# Altium schematic sheet cooling_fan.SchDoc
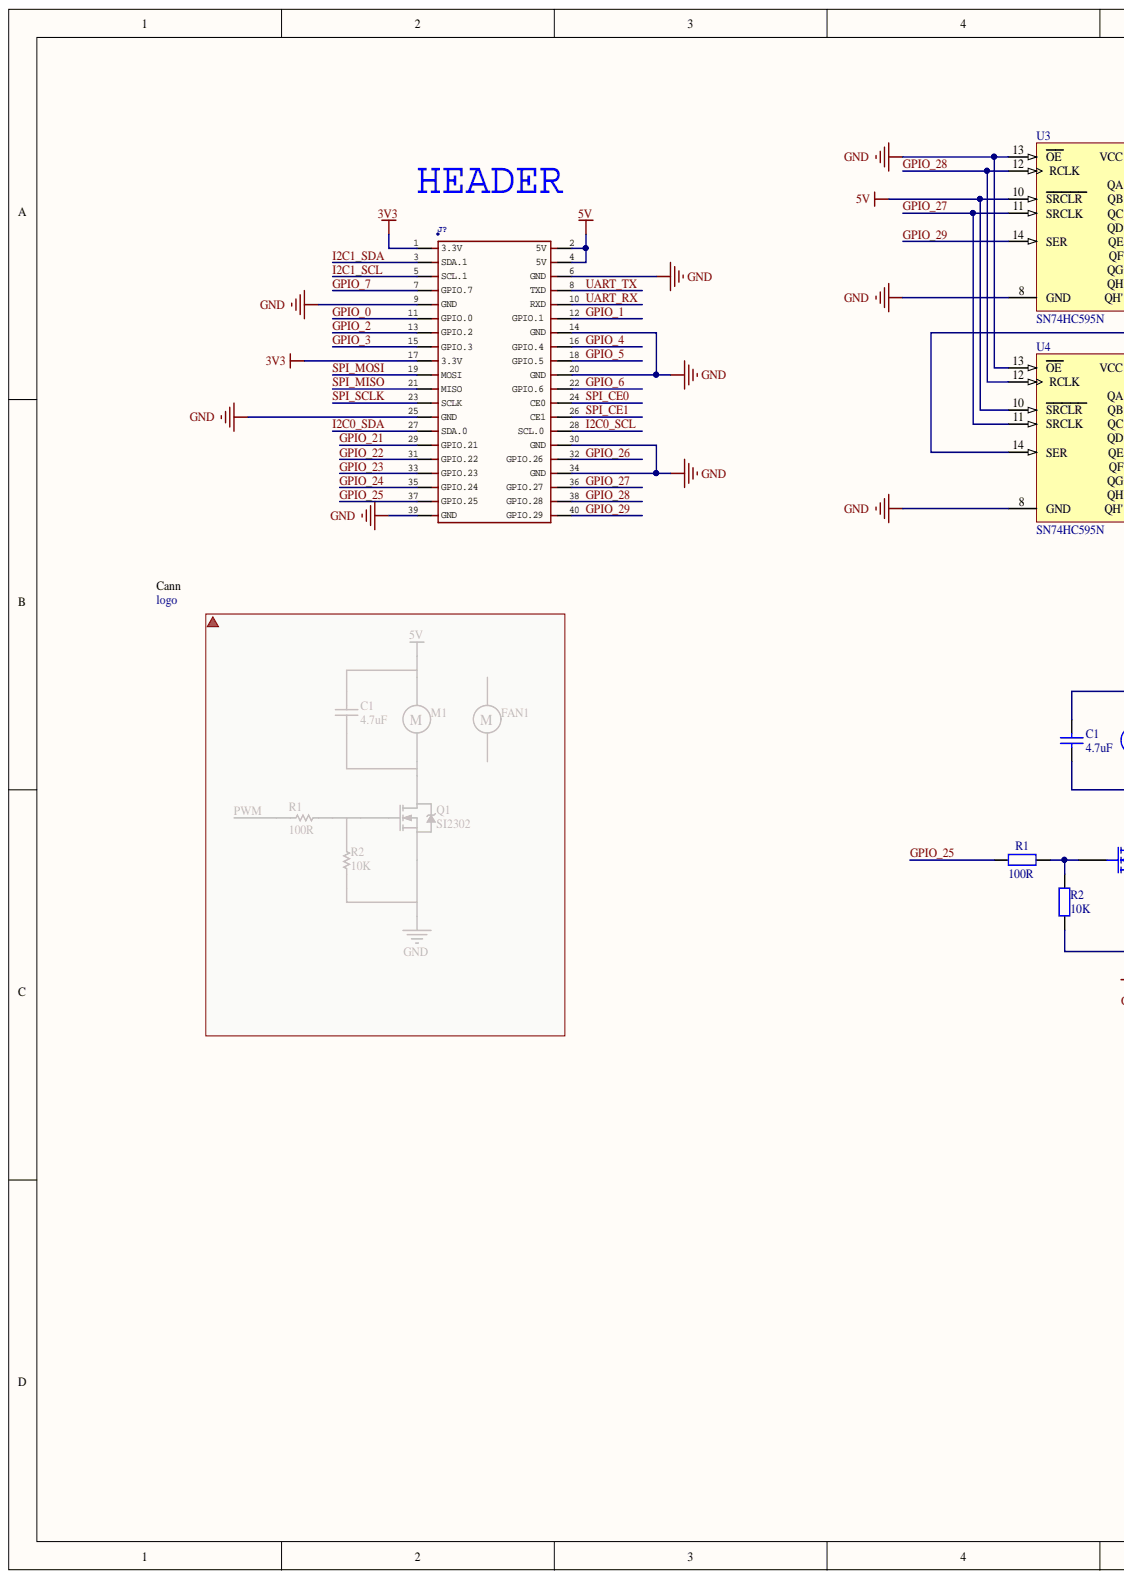
[diagram p0: cooling_fan.SchDoc, left]
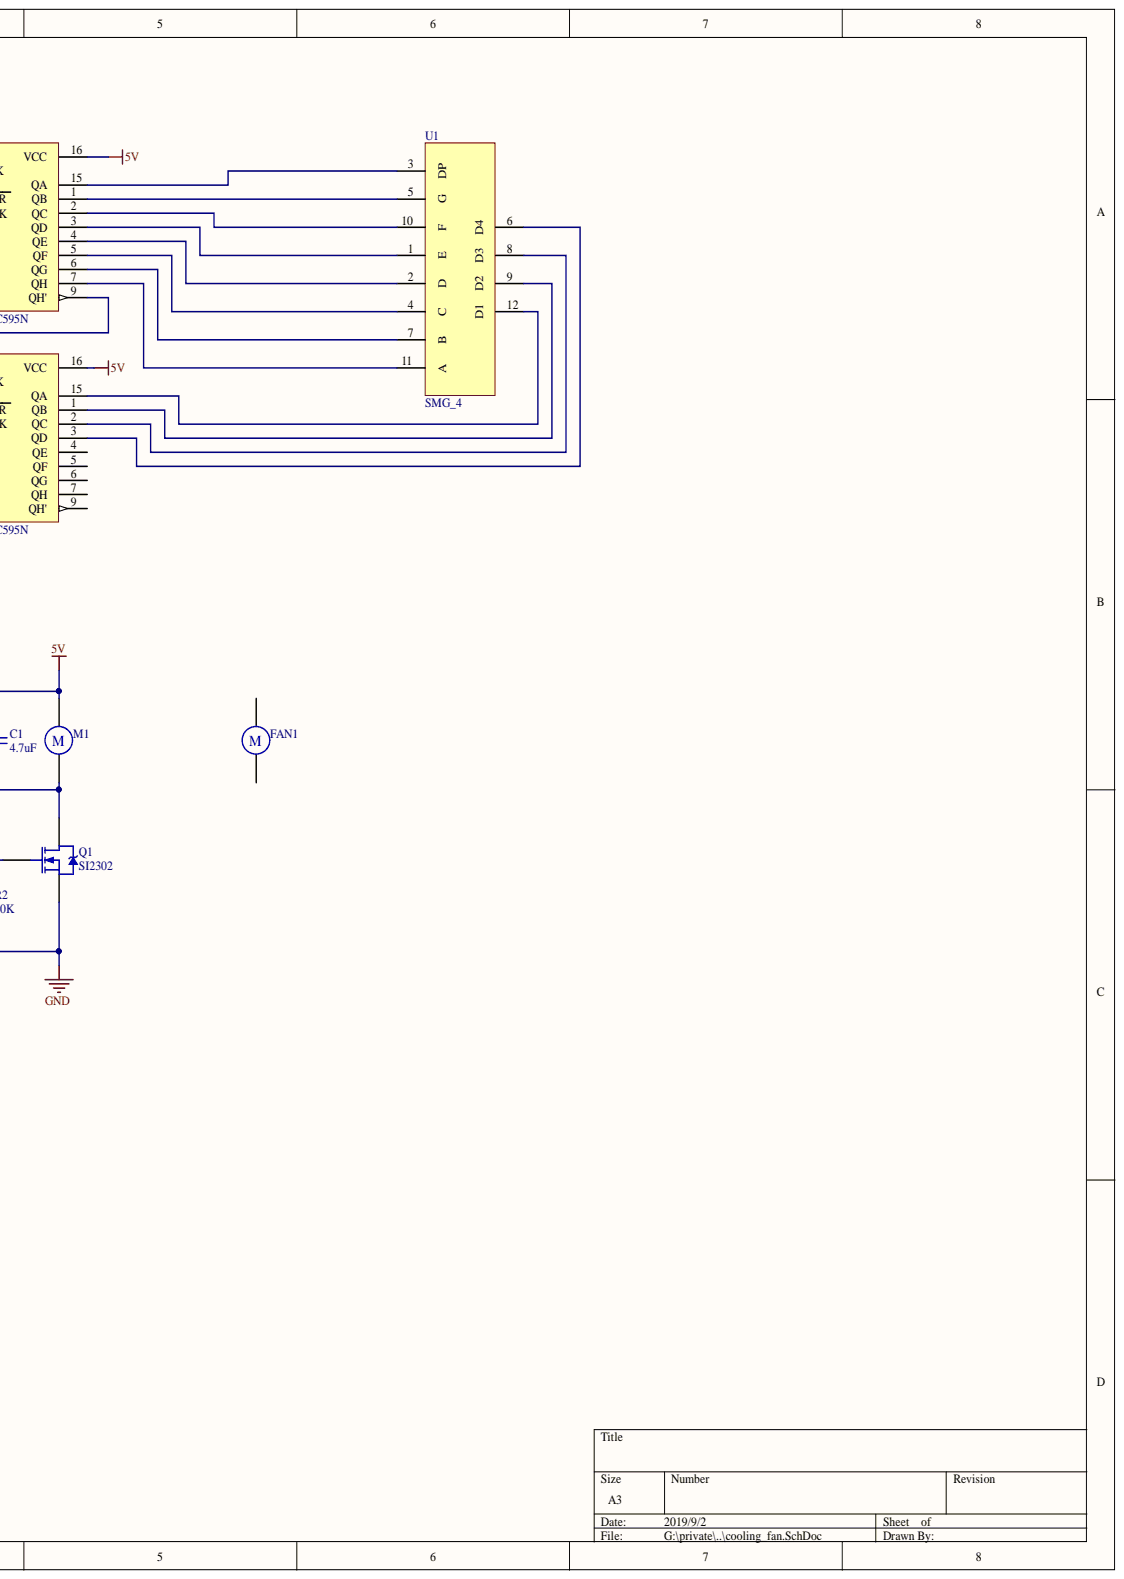
[diagram p1: cooling_fan.SchDoc, right]

## Parts
C1  value 4.7uF  [0603_C]  (hidden comment: C_0603)
FAN1  Motor_720  [FAN]  (value not drawn)
J?  [320030075]2*13P-2.54  [HEADER_20X2]  (value not drawn)
logo1  logo  [LOGO_muselab]
M1  Motor_720  [PH2.0-WS-2P]  (value not drawn)
Q1  SI2302  [SOT-23(SOT-23-3)]
R1  value 100R  [0603_R]  (hidden comment: R_0603)
R2  value 10K  [0603_R]  (hidden comment: R_0603)
U1  SMG_4  [SMG 0.28-4P_B]
U3  SN74HC595N  [SOP16N_M]
U4  SN74HC595N  [SOP16N_M]

## Nets
3V3: J?.1(3.3V) J?.17(3.3V)
5V: C1.2 J?.2(5V) J?.4(5V) M1.1 U3.10(SRCLR) U3.16(VCC) U4.10(SRCLR) U4.16(VCC)
GND: J?.6(GND) J?.9(GND) J?.14(GND) J?.20(GND) J?.25(GND) J?.30(GND) J?.34(GND) J?.39(GND) Q1.2 R2.1 U3.8(GND) U3.13(OE) U4.8(GND) U4.13(OE)
GPIO_0: J?.11(GPIO.0)
GPIO_1: J?.12(GPIO.1)
GPIO_2: J?.13(GPIO.2)
GPIO_3: J?.15(GPIO.3)
GPIO_4: J?.16(GPIO.4)
GPIO_5: J?.18(GPIO.5)
GPIO_6: J?.22(GPIO.6)
GPIO_7: J?.7(GPIO.7)
GPIO_21: J?.29(GPIO.21)
GPIO_22: J?.31(GPIO.22)
GPIO_23: J?.33(GPIO.23)
GPIO_24: J?.35(GPIO.24)
GPIO_25: J?.37(GPIO.25) R1.1
GPIO_26: J?.32(GPIO.26)
GPIO_27: J?.36(GPIO.27) U3.11(SRCLK) U4.11(SRCLK)
GPIO_28: J?.38(GPIO.28) U3.12(RCLK) U4.12(RCLK)
GPIO_29: J?.40(GPIO.29) U3.14(SER)
I2C0_SCL: J?.28(SCL.0)
I2C0_SDA: J?.27(SDA.0)
I2C1_SCL: J?.5(SCL.1)
I2C1_SDA: J?.3(SDA.1)
SPI_CE0: J?.24(CE0)
SPI_CE1: J?.26(CE1)
SPI_MISO: J?.21(MISO)
SPI_MOSI: J?.19(MOSI)
SPI_SCLK: J?.23(SCLK)
UART_RX: J?.10(RXD)
UART_TX: J?.8(TXD)
NetC1_1: C1.1 M1.2 Q1.3
NetQ1_1: Q1.1 R1.2 R2.2
NetU1_1: U1.1(E) U3.3(QD)
NetU1_2: U1.2(D) U3.4(QE)
NetU1_3: U1.3(DP) U3.15(QA)
NetU1_4: U1.4(C) U3.5(QF)
NetU1_5: U1.5(G) U3.1(QB)
NetU1_6: U1.6(D4) U4.3(QD)
NetU1_7: U1.7(B) U3.6(QG)
NetU1_8: U1.8(D3) U4.2(QC)
NetU1_9: U1.9(D2) U4.1(QB)
NetU1_10: U1.10(F) U3.2(QC)
NetU1_11: U1.11(A) U3.7(QH)
NetU1_12: U1.12(D1) U4.15(QA)
NetU3_9: U3.9(QH') U4.14(SER)

Not connected: FAN1 1 2; U4 4(QE) 5(QF) 6(QG) 7(QH) 9(QH')

## Library data of the parts
FAN1 M1 = Motor_720: Motor, General Kind; Component Kind: Standard; Library Name: RoboFly.SchLib; PackageReference: RB5-10.5; Published: 8-August-2017; Publisher: mazhongwei
J? = RPI-HEADER-2X20P: Component Kind: Standard; Library Name: programmer.SCHLIB
Q1 = SI2302: N-Channel Power MOSFET; Component Kind: Standard; Library Name: RoboFly.SchLib; PackageReference: SOT23-3; Published: 8-August-2017; Publisher: mazhongwei
U3 U4 = SN74HC595N: 8-Bit Shift Register with 3-State Output Registers; Code_JEDEC: MS-001-BB; Component Kind: Standard; ComponentLink1Description: Manufacturer Link; ComponentLink1URL: http://www.ti.com/; ComponentLink2Description: Datasheet; ComponentLink2URL: http://www-s.ti.com/sc/ds/sn74hc595.pdf; DatasheetVersion: May-1997; LatestRevisionDate: 04-Mar-2005; LatestRevisionNote: Stylized 3D Model Added.; Library Name: TI Logic Register.IntLib; PackageDescription: DIP; 16 Leads; Row Spacing 7.62 mm; Pitch 2.54 mm; PackageReference: N016; PackageVersion: Oct-1995; Published: 8-Jun-2000; Publisher: Altium Limited
C1 = C_0603: Component Kind: Standard; LatestRevisionDate: 17-Jul-2002; LatestRevisionNote: Re-released for DXP Platform.; Library Name: RoboFly.SchLib; PackageDescription: Radial Cap, Thru-Hole; 2 Leads; 0.3 in Pin Spacing; PackageReference: RAD-0.3; Published: 8-Jun-2000; Publisher: Altium Limited
logo1 = logo: Component Kind: Standard; Library Name: nanoSTM32.SCHLIB
R1 R2 = R_0603: Component Kind: Standard; LatestRevisionDate: 17-Jul-2002; LatestRevisionNote: Re-released for DXP Platform.; Library Name: RoboFly.SchLib; PackageDescription: Axial Device, Thru-Hole; 2 Leads; 0.4 in Pin Spacing; PackageReference: AXIAL-0.4; Published: 8-Jun-2000; Publisher: Altium Limited
U1 = SMG_4: Component Kind: Standard; Library Name: cooling_fan.SCHLIB
